# Altium schematic sheet 03_IO-CONNECTION.SchDoc
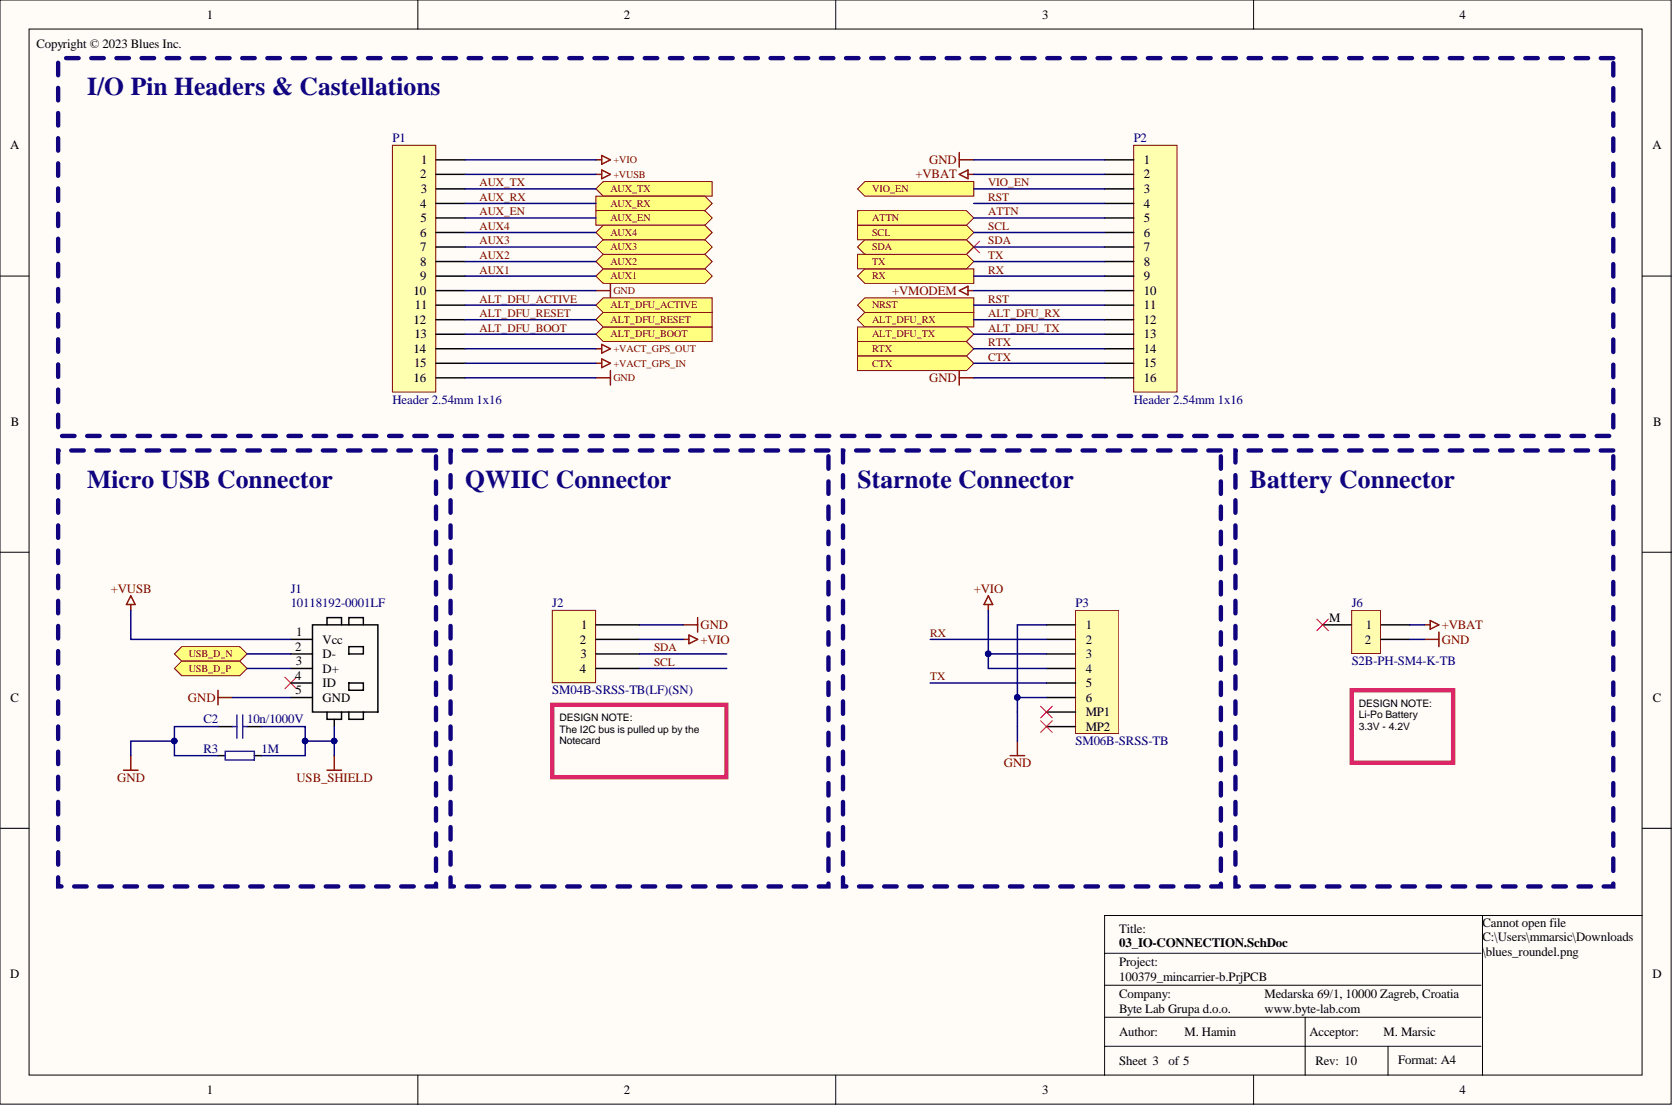
[diagram p0: 03_IO-CONNECTION.SchDoc]

## Parts
C2  10n/1000V  [C-1206]
J1  10118192-0001LF  [Conn_USB_B_Micro_-_10118192-0001LF]
J2  SM04B-SRSS-TB(LF)(SN)  [JST SM04B-SRSS-TB]
J6  S2B-PH-SM4-K-TB  [Conn S2B-PH-SM4]
P1  Header 2.54mm 1x16  [Header 2.54mm 1X16]
P2  Header 2.54mm 1x16  [Header 2.54mm 1X16]
P3  SM06B-SRSS-TB  [Conn SM06B-SRSS-TB]
R3  1M  [R-1206]

## Ports
ALT_DFU_BOOT, ALT_DFU_RESET, ALT_DFU_RX, ALT_DFU_TX, ATTN, AUX1, AUX2, AUX3, AUX4, AUX_EN, AUX_RX, AUX_TX, CTX, NRST, RTX, RX, SCL, SDA, TX, USB_D_N, USB_D_P, VIO_EN, ~{ALT_DFU_ACTIVE}

## Nets
+VACT_GPS_IN: P1.15
+VACT_GPS_OUT: P1.14
+VBAT: J6.1 P2.2
+VIO: J2.2 P1.1 P3.3 P3.4
+VMODEM: P2.10
+VUSB: J1.1(Vcc) P1.2
GND: C2.2 J1.5(GND) J2.1 J6.2 P1.10 P1.16 P2.1 P2.16 P3.1 P3.6 R3.2
USB_SHIELD: C2.1 J1.M R3.1
~{ALT_DFU_ACTIVE}: P1.11 ; port ~{ALT_DFU_ACTIVE}
~{RST}: P2.11 ; port NRST
ALT_DFU_BOOT: P1.13 ; port ALT_DFU_BOOT
ALT_DFU_RESET: P1.12 ; port ALT_DFU_RESET
ALT_DFU_RX: P2.12 ; port ALT_DFU_RX
ALT_DFU_TX: P2.13 ; port ALT_DFU_TX
ATTN: P2.5 ; port ATTN
AUX1: P1.9 ; port AUX1
AUX2: P1.8 ; port AUX2
AUX3: P1.7 ; port AUX3
AUX4: P1.6 ; port AUX4
AUX_EN: P1.5 ; port AUX_EN
AUX_RX: P1.4 ; port AUX_RX
AUX_TX: P1.3 ; port AUX_TX
CTX: P2.15 ; port CTX
RST: P2.4
RTX: P2.14 ; port RTX
RX: P2.9 P3.2 ; port RX
SCL: J2.4 P2.6 ; port SCL
SDA: J2.3 P2.7 ; port SDA
TX: P2.8 P3.5 ; port TX
USB_D_N: J1.2(D-) ; port USB_D_N
USB_D_P: J1.3(D+) ; port USB_D_P
VIO_EN: P2.3 ; port VIO_EN

Not connected: J1 4(ID); J6 M; P3 MP1 MP2

## Library data of the parts
C2 = C1206-10n-1000V-X7R - CS1206KKX7RCBB103: Capacitor ceramic 10n ±10% X7R 1000V 1206; BL Part Number: 2007-449; Manufacturer: YAGEO; Drawn_By: Hamin; Component Kind: Standard; DateModified: 03-05-2023; Library Name: BL_Passive.SchLib; Manufacturer Part Number: CS1206KKX7RCBB103; Supplier 1: Digi-Key; Supplier Part Number 1: 311-3578-1-ND
J1 = Conn USB B Micro - 10118192-0001LF: Connector; Micro B , SMD; 5 Position; Right Angle; BL Part Number: 2002-715; Manufacturer: FCI; Checked_By: Zvonc; Drawn_By: Puskar; Component Kind: Standard; DateModified: 25-03-2015; Library Name: BL_Mechanical.SchLib; Manufacturer Part Number: 10118192-0001LF; Supplier 1: Digi-Key; Supplier Part Number 1: 609-4613-1-ND
J2 = SM04B-SRSS-TB(LF)(SN): CONN HEADER SH 4POS SIDE 1MM TIN; BL Part Number: 2002-058; Manufacturer: JST Sales America Inc.; Drawn_By: Blues Wireless; Component Kind: Standard; Library Name: n21-p2.SchLib; Manufacturer Part Number: SM04B-SRSS-TB(LF)(SN); Supplier 1: Digi-Key; Supplier Part Number 1: 455-1804-1-ND
J6 = Conn S2B-PH-SM4-K-TB: 2.00mm pitch 2 pin side entry wire to board connector, SMD; BL Part Number: 2007-662; Manufacturer: JST; Manufacturer Part Number: S2B-PH-SM4-K-TB(LF)(SN); Drawn_By: Hamin; Component Kind: Standard; DateModified: 19-06-2023; Library Name: BL_Mechanical.SchLib; Supplier 1: Digi-Key
P1 P2 = Conn Header 2.54mm 1X16: 2.54mm pitch 16 pin (1x16) top entry wire to board connector THT; BL Part Number: 2001-478; Checked_By: Hamin; Drawn_By: Puskar; Component Kind: Standard; DateModified: 07-12-2013; Library Name: BL_Mechanical.SchLib; Manufacturer: N/A; Manufacturer Part Number: N/A
P3 = Conn SM06B-SRSS-TB: 1mm pitch 6 pin side entry wire to board connector, SMD; BL Part Number: 2008-220; Manufacturer: JST; Checked_By: Marsic; Drawn_By: Gudan; Component Kind: Standard; DateModified: 18-01-2024; Library Name: BL_Mechanical.SchLib; Manufacturer Part Number: SM06B-SRSS-TB; Supplier 1: Digi-Key; Supplier Part Number 1: 455-SM06B-SRSS-TBTR-ND
R3 = R1206-1M-1: Resistor 1M ±1% 1206; BL Part Number: 2001-438; Checked_By: Golubic; Drawn_By: Zvonc; Component Kind: Standard; DateModified: 01-07-2014; Library Name: BL_Passive.SchLib; Manufacturer: N/A; Manufacturer Part Number: N/A
